# Altium schematic sheet Battery.SchDoc
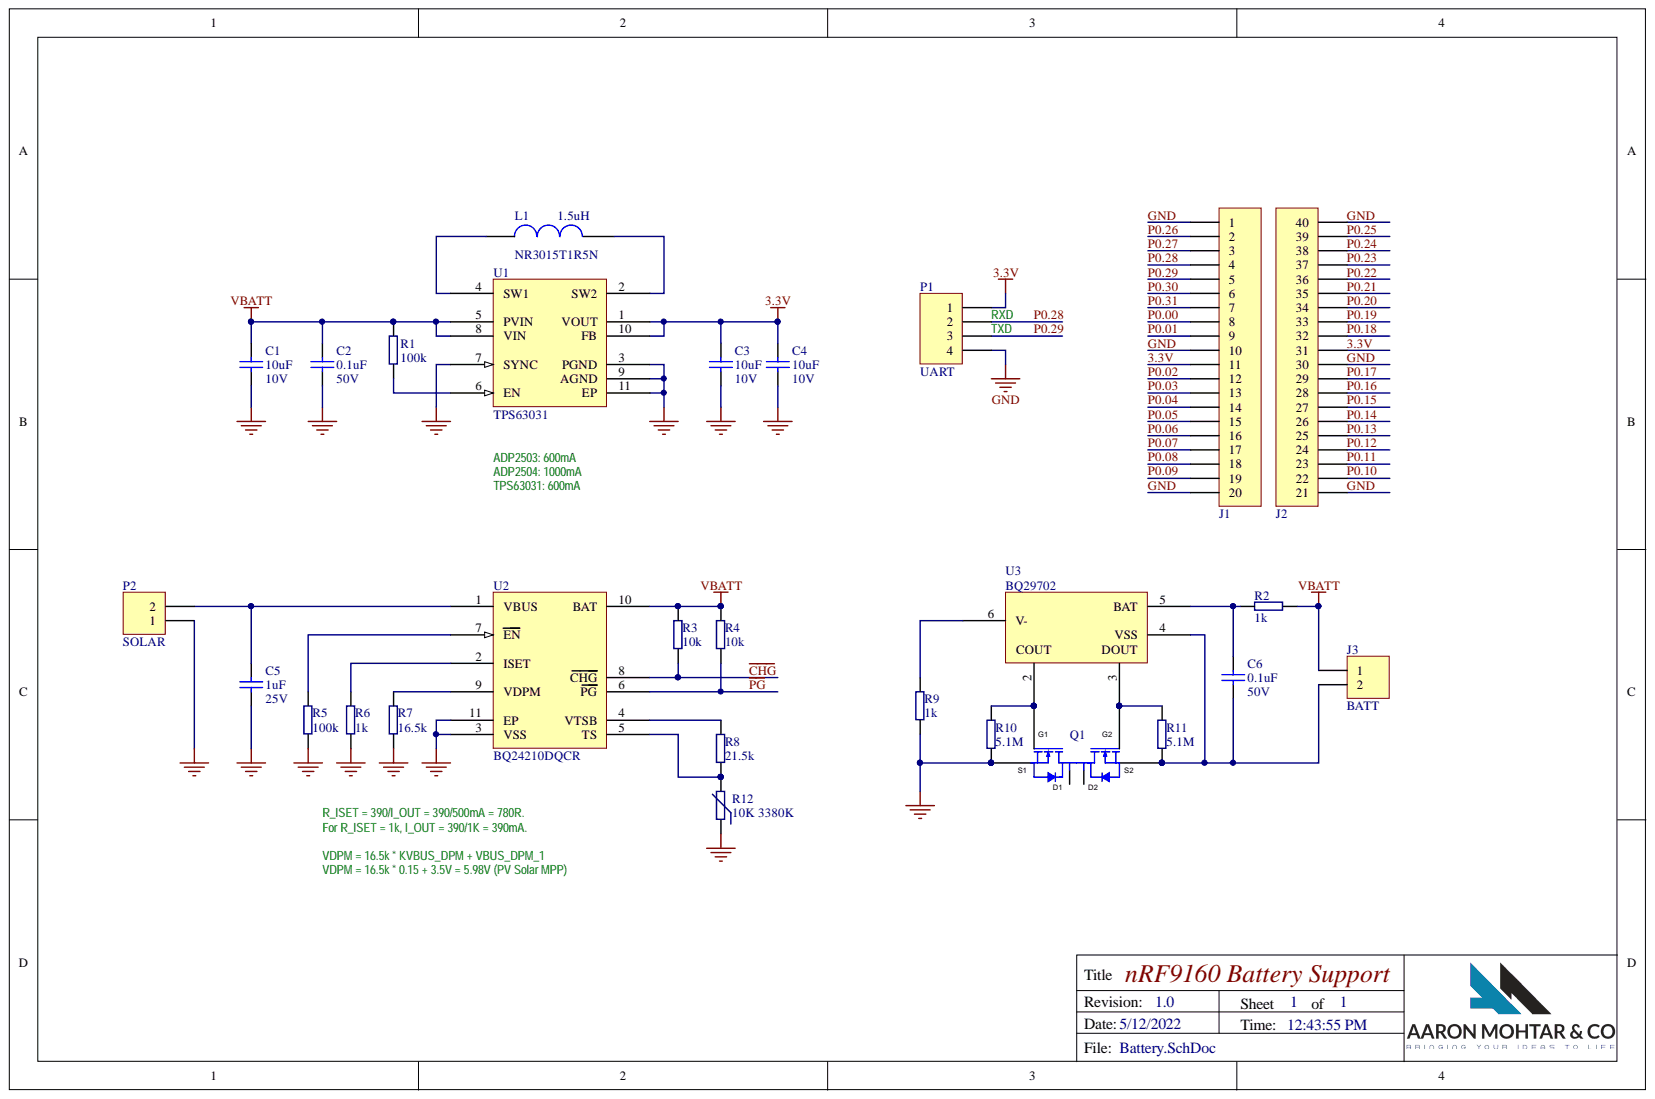
[diagram p0: Battery.SchDoc]

## Parts
C1  10uF  Voltage: 10V  [CAPC0603(1608)100_N]
C2  0.1uF  Voltage: 50V  [CAPC0603(1608)100_N]
C3  10uF  Voltage: 10V  [CAPC0603(1608)100_N]
C4  10uF  Voltage: 10V  [CAPC0603(1608)100_N]
C5  1uF  Voltage: 25V  [CAPC0603(1608)100_N]
C6  0.1uF  Voltage: 50V  [CAPC0603(1608)100_N]
J1  CONN RCPT 20POS 0.1 GOLD PCB  [SSQ-120-03-G-S]  (value not drawn)
J2  HDR 2.54 VERT SIL 20POS (B)  [SSQ-120-03-G-S-(B)]  (value not drawn)
J3  BATT  [S2B-PH-SM4-TB(LF)(SN)-2_V]
L1  1.5uH  PartNumber: NR3015T1R5N  [TAYO-IND0315_V]
P1  UART  [MOLEX SL 0705530003]
P2  SOLAR  [MOLEX SL 0705530001]
Q1  DMG9926  [FP-SOT26_SC74R-IPC_B]  (value not drawn)
R1  100k  [RESC0603(1608)_N]
R2  1k  [RESC0603(1608)_N]
R3  10k  [RESC0603(1608)_N]
R4  10k  [RESC0603(1608)_N]
R5  100k  [RESC0603(1608)_N]
R6  1k  [RESC0603(1608)_N]
R7  16.5k  [RESC0603(1608)_N]
R8  21.5k  [RESC0603(1608)_N]
R9  1k  [RESC0603(1608)_N]
R10  5.1M  [RESC0603(1608)_N]
R11  5.1M  [RESC0603(1608)_N]
R12  10K 3380K  [RESC0603(1608)_N]
U1  TPS63031  [ADI-CP-10-9_V]
U2  BQ24210DQCR  [SON50P300X200X80-11N]
U3  BQ29702  [FP-DSE0006A-IPC_C]

## Nets
VBATT: C1.2 C2.2 J3.1 R1.2 R2.2 R3.2 R4.2 U1.5(PVIN) U1.8(VIN) U2.10(BAT)
3.3V: C3.2 C4.2 J1.11 J2.31 P1.1 U1.1(VOUT) U1.10(FB)
~{CHG}: R3.1 U2.8(CHG)
GND: C1.1 C2.1 C3.1 C4.1 C5.1 J1.1 J1.10 J1.20 J2.21 J2.30 J2.40 P1.4 P2.1 Q1.1 R5.1 R6.1 R7.1 R9.1 R10.1 R12.1 U1.3(PGND) U1.7(SYNC) U1.9(AGND) U1.11(EP) U2.3(VSS) U2.11(EP)
P0.00: J1.8
P0.01: J1.9
P0.02: J1.12
P0.03: J1.13
P0.04: J1.14
P0.05: J1.15
P0.06: J1.16
P0.07: J1.17
P0.08: J1.18
P0.09: J1.19
P0.10: J2.22
P0.11: J2.23
P0.12: J2.24
P0.13: J2.25
P0.14: J2.26
P0.15: J2.27
P0.16: J2.28
P0.17: J2.29
P0.18: J2.32
P0.19: J2.33
P0.20: J2.34
P0.21: J2.35
P0.22: J2.36
P0.23: J2.37
P0.24: J2.38
P0.25: J2.39
P0.26: J1.2
P0.27: J1.3
P0.28: J1.4 P1.2
P0.29: J1.5 P1.3
P0.30: J1.6
P0.31: J1.7
~{PG}: R4.1 U2.6(PG)
NetC5_2: C5.2 P2.2 U2.1(VBUS)
NetC6_1: C6.1 J3.2 Q1.3 R11.1 U3.4(VSS)
NetC6_2: C6.2 R2.1 U3.5(BAT)
NetL1_1: L1.1 U1.4(SW1)
NetL1_2: L1.2 U1.2(SW2)
NetQ1_4: Q1.4 R11.2 U3.3
NetQ1_6: Q1.6 R10.2 U3.2
NetR1_1: R1.1 U1.6(EN)
NetR5_2: R5.2 U2.7(EN)
NetR6_2: R6.2 U2.2(ISET)
NetR7_2: R7.2 U2.9(VDPM)
NetR8_1: R8.1 R12.2 U2.5(TS)
NetR8_2: R8.2 U2.4(VTSB)
NetR9_2: R9.2 U3.6(V-)

Not connected: Q1 2 5

## Library data of the parts
C1 C3 C4 = CAP 10uF 10V 0603: CAP CER 10UF 10V X5R 0603; Manufacturer: Taiyo Yuden; PartNumber: LMK107BBJ106MALT; DK code: 587-3258-1-ND; Component Kind: Standard; Library Name: Passives.SchLib; Operating temperature: -55°C ~ 85°C; PackageDescription: 0603; Temperature coefficient: X5R; Value: 10uF
C2 C6 = CAP 0.1uF 50V 0603: CAP CER 0.1UF 50V X7R 0603; Manufacturer: AVX Corporation; PartNumber: 06035C104KAT2A; DK code: 478-5052-1-ND; Component Kind: Standard; Library Name: Passives.SchLib; Operating temperature: -55°C ~ 125°C; PackageDescription: 0603; Temperature coefficient: X7R; Value: 0.1uF
C5 = CAP 1uF 25V 0603: CAP CER 1UF 25V X7R 0603; Manufacturer: Samsung Electro-Mechanics; PartNumber: CL10B105KA8NNNC; DK code: 1276-1184-1-ND; Component Kind: Standard; Library Name: Passives.SchLib; Operating temperature: -55°C ~ 125°C; PackageDescription: 0603; Temperature coefficient: X7R; Value: 1uF
J1 = HDR 2.54 VERT SIL 20POS: Manufacturer: Samtec Inc.; DK code: SAM1198-20-ND; Component Kind: Standard; Description: CONN RCPT 20POS 0.1 GOLD PCB; Library Name: connectors.IntLib; PartNumber: SSQ-120-03-G-S
J2 = HDR 2.54 VERT SIL 20POS (B): CONN HEADER VERT 20POS 2.54MM; Manufacturer: Harwin Inc.; PartNumber: M20-9992046; DK code: 952-3306-ND; Component Kind: Standard; Library Name: connectors.IntLib
J3 = JST CONN HDR SMD R/A 2POS 2MM: CONN HEADER VERT 2POS 2.54MM; Manufacturer: JST Sales America Inc.; PartNumber: S2B-PH-SM4-TB(LF)(SN); DK code: 455-1749-1-ND; Component Kind: Standard; Library Name: Connectors.SchLib
L1 = IND 1.5uH 1.82A: FIXED IND 1.5UH 1.82A 48MOHM SMD; Manufacturer: Taiyo Yuden; DK code: 587-2617-1-ND; Component Kind: Standard; Library Name: Passives.SchLib; Value: 1.5uH
P1 = MOLEX SL HDR 2.54 RA SIL 4POS: CONN HEADER R/A 4POS 2.54MM; Manufacturer: Molex, LLC; PartNumber: 0705530003; DK code: WM4902-ND; Component Kind: Standard; Library Name: Connectors.SchLib
P2 = MOLEX SL HDR 2.54 RA SIL 2POS: CONN HEADER R/A 2POS 2.54MM; Manufacturer: Molex, LLC; PartNumber: 0705530001; DK code: WM4900-ND; Component Kind: Standard; Library Name: Connectors.SchLib
Q1 = DMG9926UDM: MOSFET 2N-CH 20V 4.2A SOT-26; Manufacturer: Diodes Incorporated; PartNumber: DMG9926UDM-7; DK code: DMG9926UDMDITR-ND; Component Kind: Standard; Library Name: Discretes.SchLib; Package: SOT-26
R1 R5 = RES 100K 0603: RES SMD 100K OHM 1% 1/10W 0603; Manufacturer: Yageo; PartNumber: RC0603FR-07100KL; DK code: 311-100KHRCT-ND; Component Kind: Standard; Library Name: Passives.SchLib; PackageDescription: 0603; Tolerance: 1%; Value: 100k
R2 R6 R9 = RES 1K 0603: RES SMD 1K OHM 1% 1/10W 0603; Manufacturer: Yageo; PartNumber: RC0603FR-071KL; DK code: 311-1.00KHRCT-ND; Component Kind: Standard; Library Name: Passives.SchLib; PackageDescription: 0603; Tolerance: 1%; Value: 1k
R3 R4 = RES 10K 0603: RES SMD 10K OHM 1% 1/10W 0603; Manufacturer: Yageo; PartNumber: RC0603FR-0710KL; DK code: 311-10.0KHRCT-ND; Component Kind: Standard; Library Name: Passives.SchLib; PackageDescription: 0603; Tolerance: 1%; Value: 10k
R7 = RES 16.5K 0603: RES SMD 16.5K OHM 1% 1/10W 0603; Manufacturer: Yageo; PartNumber: RC0603FR-0716K5L; DK code: 311-16.5KHRCT-ND; Component Kind: Standard; Library Name: Passives.SchLib; PackageDescription: 0603; Tolerance: 1%; Value: 16.5k
R8 = RES 21.5K 0603: RES SMD 21.5K OHM 1% 1/10W 0603; Manufacturer: Yageo; PartNumber: RC0603FR-0721K5L; DK code: 311-21.5KHRCT-ND; Component Kind: Standard; Library Name: Passives.SchLib; PackageDescription: 0603; Tolerance: 1%; Value: 21.5k
R10 R11 = RES 5.1M 0603: RES SMD 5.1M OHM 1% 1/10W 0603; Manufacturer: Yageo; PartNumber: RC0603FR-075M1L; DK code: 13-RC0603FR-075M1LCT-ND; Component Kind: Standard; Library Name: Passives.SchLib; PackageDescription: 0603; Tolerance: 1%; Value: 5.1M
R12 = THERMISTOR NTC 10K 0603: THERMISTOR NTC 10KOHM 3380K 0603; Manufacturer: Murata Electronics; PartNumber: NCU18XH103F60RB; DK code: 490-16279-1-ND; Tolerance: 1%; Component Kind: Standard; Library Name: Passives.SchLib; PackageDescription: 0603; Value: 10K 3380K
U1 = TPS63031: IC REG BCK BST 3.3V 900MA 10SON; Manufacturer: Texas Instruments; PartNumber: TPS63031DSKR; DK code: 296-39461-2-ND; Component Kind: Standard; Library Name: ICs.SchLib; PackageDescription: 10-WFDFN
U2 = BQ24210DQCR: IC BATT CHG LI-ION 1CELL 10WSON; Manufacturer: Texas Instruments; DK code: 296-BQ24210DQCRTR-ND; Component Kind: Standard; Package: WSON-10; PartNumber: BQ24210DQCR; Library Name: IntergratedCircuits.SchLib
U3 = BQ29702DSET: IC BATT PROT LI-ION 1CELL 6WSON; Manufacturer: Texas Instruments; DK code: 296-46885-1-ND; Component Kind: Standard; Library Name: ICs.SchLib; PackageDescription: 6WSON; PartNumber: BQ29702DSET
